# Altium schematic sheet Sheet3.SchDoc
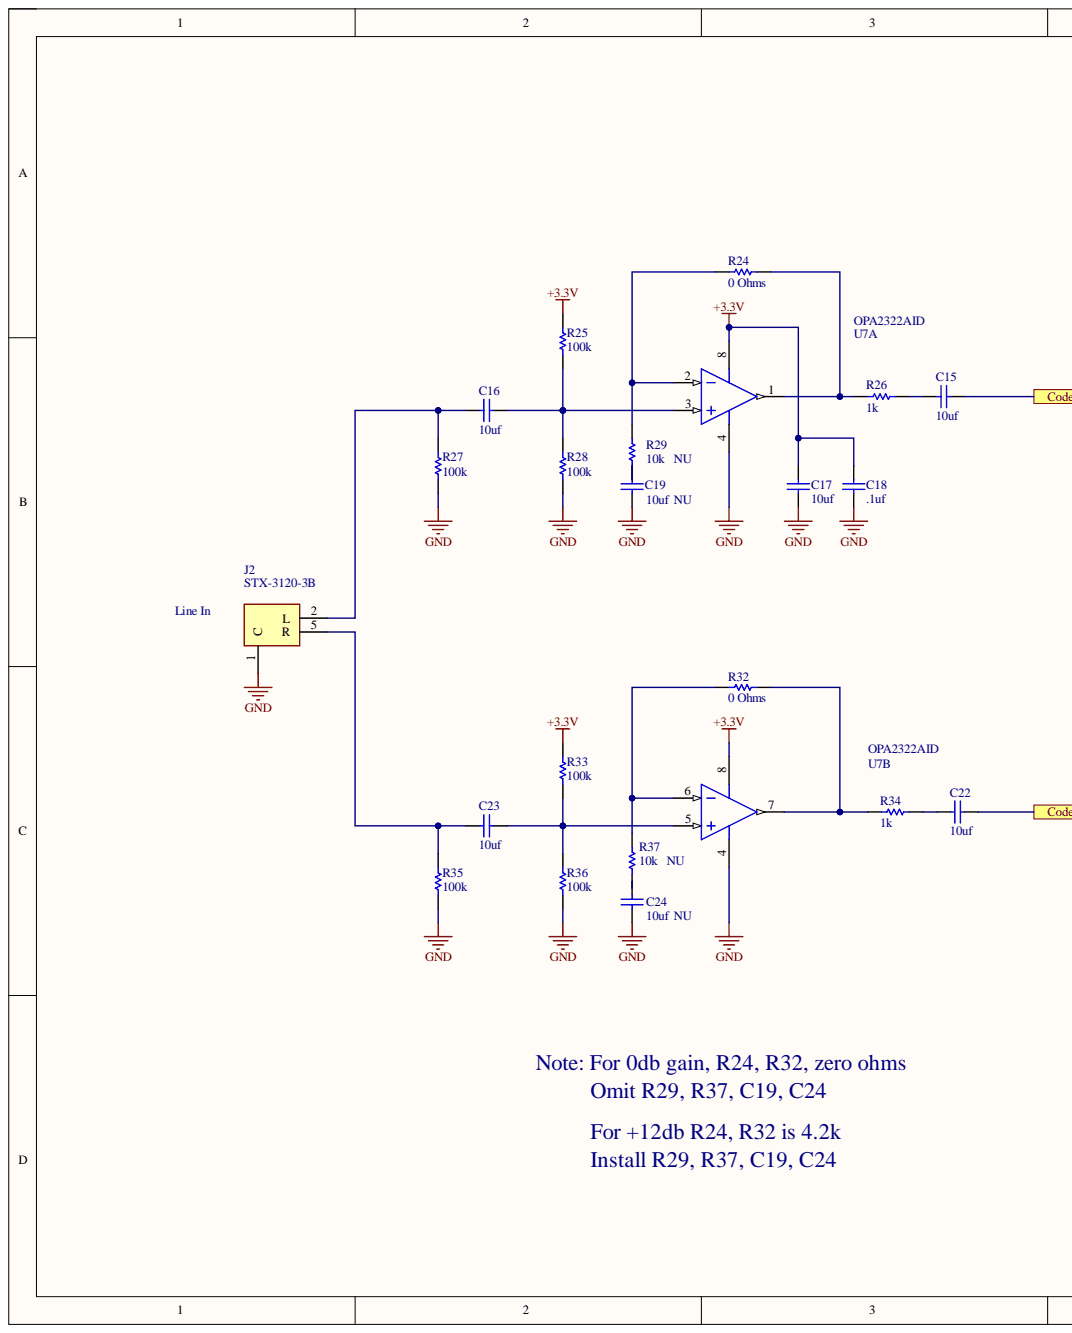
[diagram p0: Sheet3.SchDoc, left]
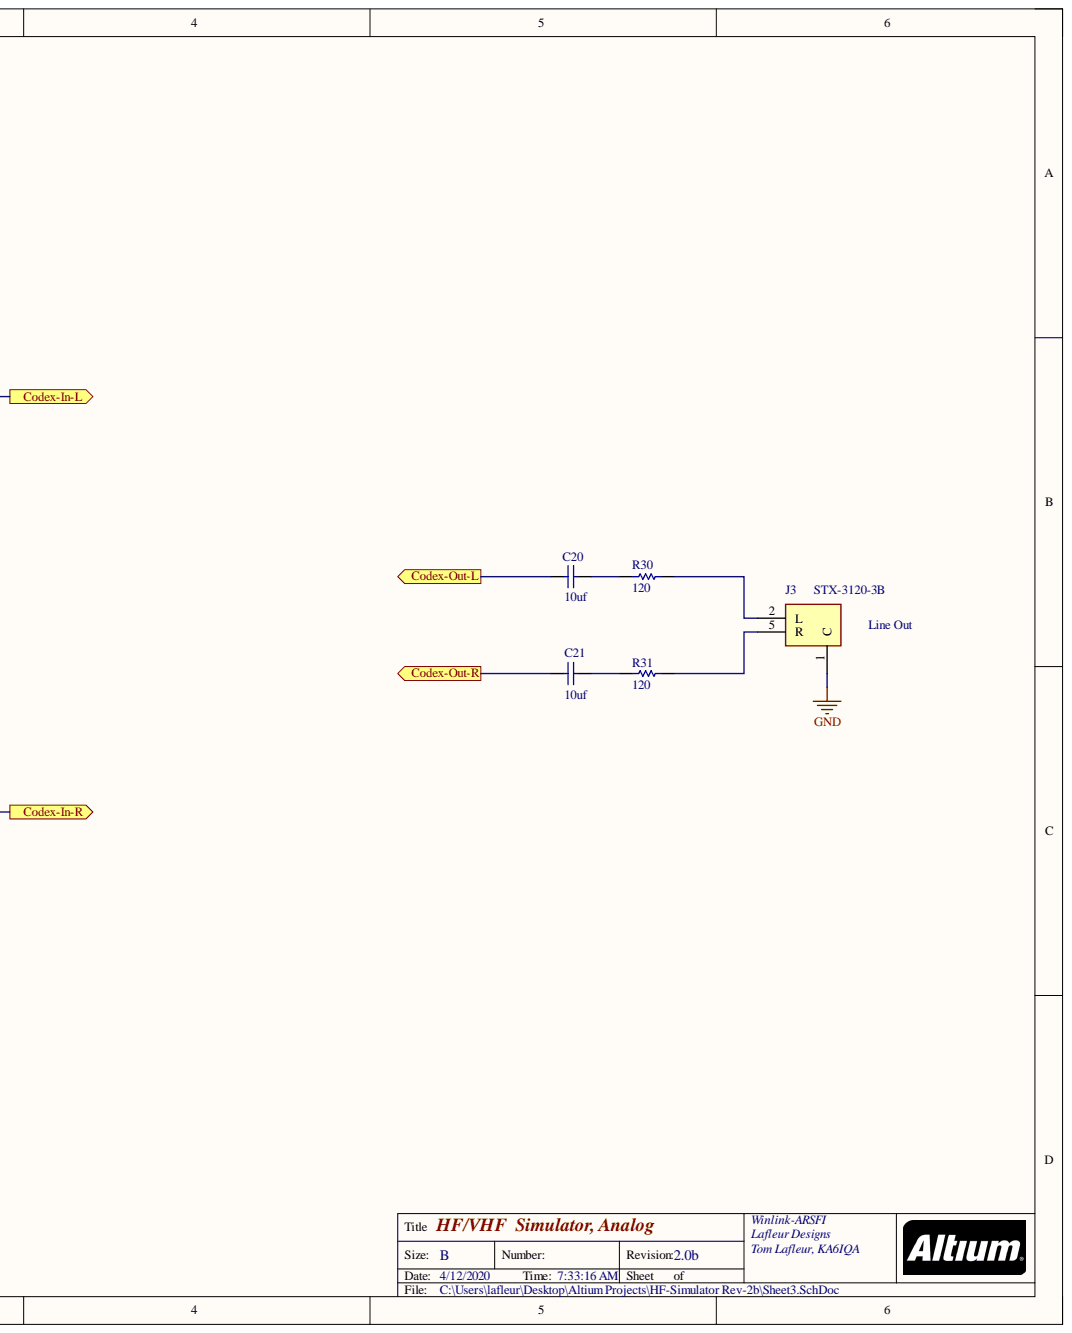
[diagram p1: Sheet3.SchDoc, right]

## Parts
C15  10uf  [6-0805]
C16  10uf  [6-0805]
C17  10uf  [6-0805]
C18  .1uf  [6-0805]
C19  10uf  [6-0805]
C20  10uf  [6-0805]
C21  10uf  [6-0805]
C22  10uf  [6-0805]
C23  10uf  [6-0805]
C24  10uf  [6-0805]
J2  STX-3120-3B  [STX31203B]
J3  STX-3120-3B  [STX31203B]
R24  0 Ohms  [6-0805]
R25  100k  [6-0805]
R26  1k  [6-0805]
R27  100k  [6-0805]
R28  100k  [6-0805]
R29  10k  [6-0805]
R30  120  [6-0805]
R31  120  [6-0805]
R32  0 Ohms  [6-0805]
R33  100k  [6-0805]
R34  1k  [6-0805]
R35  100k  [6-0805]
R36  100k  [6-0805]
R37  10k  [6-0805]
U7  OPA2322AID  [D0008A_L]  (parts A,B)

## Ports
Codex-In-L, Codex-In-R, Codex-Out-L, Codex-Out-R

## Nets
+3.3V: C17.1 C18.1 R25.1 R33.1 U7.8
GND: C17.2 C18.2 C19.1 C24.1 J2.1 J3.1 R27.2 R28.2 R35.2 R36.2 U7.4
Codex-In-L: C15.2 ; port Codex-In-L
Codex-In-R: C22.2 ; port Codex-In-R
Codex-Out-L: C20.1 ; port Codex-Out-L
Codex-Out-R: C21.1 ; port Codex-Out-R
NetC15_1: C15.1 R26.1
NetC16_1: C16.1 J2.2 R27.1
NetC16_2: C16.2 R25.2 R28.1 U7.3
NetC19_2: C19.2 R29.2
NetC20_2: C20.2 R30.2
NetC21_2: C21.2 R31.2
NetC22_1: C22.1 R34.1
NetC23_1: C23.1 J2.5 R35.1
NetC23_2: C23.2 R33.2 R36.1 U7.5
NetC24_2: C24.2 R37.2
NetJ3_2: J3.2 R30.1
NetJ3_5: J3.5 R31.1
NetR24_1: R24.1 R26.2 U7.1
NetR24_2: R24.2 R29.1 U7.2
NetR32_1: R32.1 R34.2 U7.7
NetR32_2: R32.2 R37.1 U7.6

## Library data of the parts
C15-C24 = CAP_1: Capacitor; Fitted: True; Component Kind: Standard; Library Name: N2K Project.SCHLIB
J2 J3 = STX-3120-3B: Connector; Manufacturer_Name: Kycon; Component Kind: Standard; Datasheet Link: https://componentsearchengine.com/Datasheets/1/STX-3120-3B.pdf; Height: 10.8mm; Manufacturer_Part_Number: STX-3120-3B; Mouser Part Number: 806-STX-3120-3B; Mouser Price/Stock: https://www.mouser.com/Search/Refine.aspx?Keyword=806-STX-3120-3B; Library Name: HF-Simulator Rev-1.SCHLIB
R24-R37 = ERJ8EN: Precision Thick Film Chip Resistor, 10 Ohm to 2.2M Ohm Range, 1% Tolerance, 1206 Size, 0.125 W; Code_IPC: 3216 [1206]; Component Kind: Standard; ComponentLink1Description: Manufacturer Link; ComponentLink1URL: http://www.panasonic.com/industrial/components/resistive_products.htm; ComponentLink2Description: Datasheet; ComponentLink2URL: http://www.panasonic.com/industrial/components/pdf/AOA0000CE2.pdf; LatestRevisionDate: 19-Mar-2004; LatestRevisionNote: Silkscreen size reduced.; Library Name: Panasonic Resistor.IntLib; PackageDocument: Mar-2003; PackageReference: 8-1206; Published: 17-Dec-2003; Signal Integrity: Res; Simulation: RESISTOR; Publisher: Altium Limited
U7 = CMP-0271-00129-3: 20 MHz, Low Noise, RRIO, CMOS Operational Amplifier, 1.8 to 5.5 V, -40 to 125 degC, 8-pin SOIC (D8), Green (RoHS & no Sb/Br); Manufacturer: Texas Instruments; CMRR(Min)(dB): 90; GBW(Typ)(MHz): 20; IIB(Max)(pA): 10; Iq per channel(Max)(mA): 1.75; Number of Channels: 2; Offset Drift(Typ)(uV/C): 1.8; Packing: TUBE; Rating: Catalog; Sub Family: High Output Current Amplifier (>=50mA), Low Input Bias Current Amp (<=10pA), Low Noise Amp (<=10nV/rtHz), Low Supply Voltage Amplifier (<=2.7V), Precision Amplifier; Total Supply Voltage(Max)(+5V is 5, +/-5V is 10): 5.5; Code_JEDEC: MS-012; Component Kind: Standard; ComponentLink1Description: Manufacturer URL; ComponentLink1URL: http://www.ti.com/; ComponentLink2Description: Datasheet; ComponentLink2URL: http://www.ti.com/lit/ds/symlink/opa2322.pdf; ComponentLink3Description: Package Specification; ComponentLink3URL: http://www.ti.com/litv/pdf/msoi002j; DatasheetVersion: SBOS538E; Eco-Plan: Green (RoHS & no Sb/Br); Library Name: Altium Content Vault; Mounting Technology: Surface Mount; PackageDescription: 8-Pin Plastic Small Outline, Body 4.9 x 3.9 mm, Pitch 1.27 mm; PackageReference: D0008A; PackageVersion: 4040047-3/M, 06/2011; PartId: 1; PartNumber: OPA2322AID; Slew Rate(Typ)(V/us): 10; Total Supply Voltage(Min)(+5V is 5, +/-5V is 10): 1.8; Vio (25C)(Max)(mV): 2
